# Altium schematic sheet 01_Interface.SchDoc
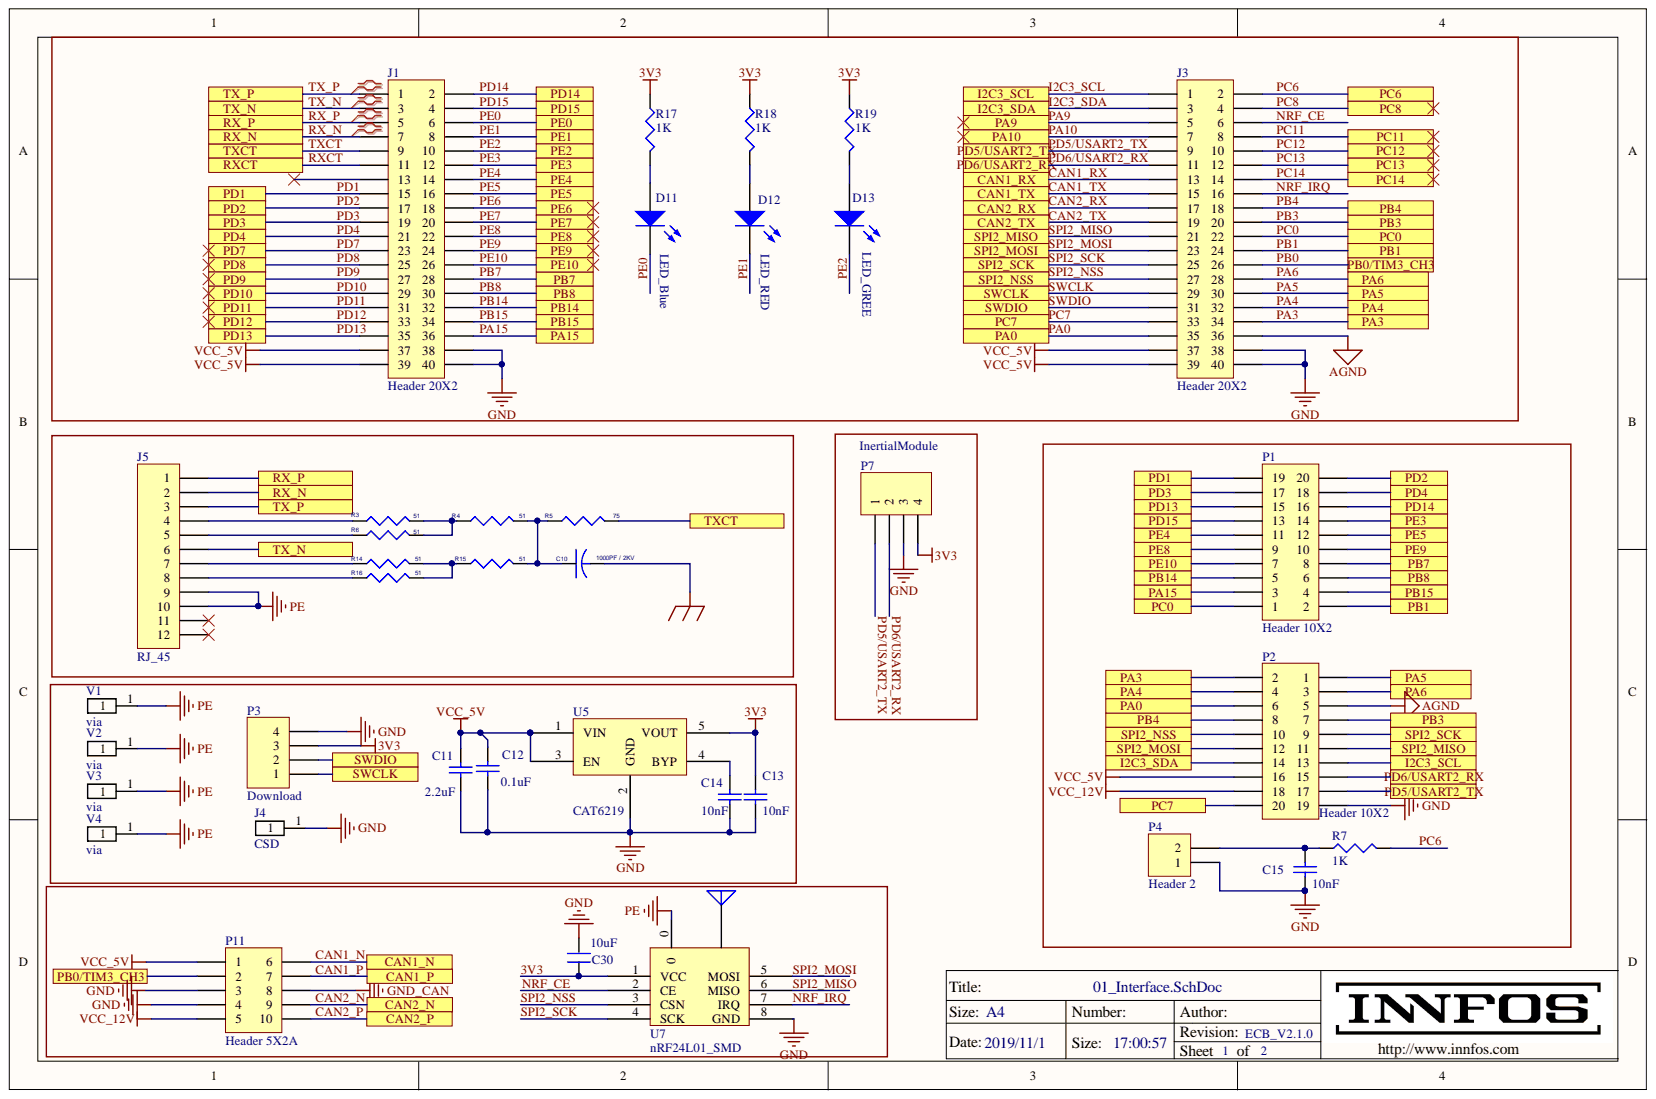
[diagram p0: 01_Interface.SchDoc]

## Parts
C10  1000PF / 2KV  [1206]
C11  2.2uF  [0402]
C12  0.1uF  [0402]
C13  10nF  [0402]
C14  10nF  [0402]
C15  10nF  [0402]
C30  10uF  [0402]
D11  LED_Blue  [LED_0603_BLUE]
D12  LED_RED  [LED_0603_RED]
D13  LED_GREE  [LED_0603_GREE]
J1  Header 20X2  [0_5_2_20_G]
J3  Header 20X2  [0_5_2_20_G]
J4  CSD  [CSD_5015]
J5  RJ_45  [RJ45_H5_5]
P1  Header 10X2  [2_10P_2_54]
P2  Header 10X2  [2_10P_2_54]
P3  Download  [Header_4p_2_54 _WZ]
P4  Header 2  [SM02B-GHS]
P7  InertialModule  [InertialModule]
P11  Header 5X2A  [Header_2_5P_2.54]
R3  51  [0402]
R4  51  [0402]
R5  75  [0402]
R6  51  [0402]
R7  1K  [0402]
R14  51  [0402]
R15  51  [0402]
R16  51  [0402]
R17  1K  [0402]
R18  1K  [0402]
R19  1K  [0402]
U5  CAT6219  [SOT23_5]
U7  nRF24L01_SMD  [nRF24L01_long]  (no BOM)
V1  via  [via_3_0]  (no BOM)
V2  via  [via_3_0]  (no BOM)
V3  via  [via_3_0]  (no BOM)
V4  via  [via_3_0]  (no BOM)

## Ports
CAN1_N, CAN1_P, CAN1_RX, CAN1_TX, CAN2_N, CAN2_P, CAN2_RX, CAN2_TX, I2C3_SCL, I2C3_SDA, PA0, PA3, PA4, PA5, PA6, PA9, PA10, PA15, PB0/TIM3_CH3, PB1, PB3, PB4, PB7, PB8, PB14, PB15, PC0, PC6, PC7, PC8, PC11, PC12, PC13, PC14, PD1, PD2, PD3, PD4, PD5/USART2_TX, PD6/USART2_RX, PD7, PD8, PD9, PD10, PD11, PD12, PD13, PD14, PD15, PE0, PE1, PE2, PE3, PE4, PE5, PE6, PE7, PE8, PE9, PE10, RX_N, RX_P, RXCT, SPI2_MISO, SPI2_MOSI, SPI2_NSS, SPI2_SCK, SWCLK, SWDIO, TX_N, TX_P, TXCT

## Nets
AGND: J3.36 P2.5
GND: C11.1 C12.1 C13.1 C14.1 C15.1 C30.2 J1.38 J1.40 J3.38 J3.40 J4.1 P2.19 P3.4 P4.1 P7.3 P11.3 P11.4 U5.2(GND) U7.8(GND)
GND_CAN: P11.8
PE: C10.2 J5.9 J5.10 U7.0 V1.1 V2.1 V3.1 V4.1
VCC_5V: C11.2 C12.2 J1.37 J1.39 J3.37 J3.39 P2.16 P11.1 U5.1(VIN) U5.3(EN)
VCC_12V: P2.18 P11.5
3V3: C13.2 C30.1 P3.3 P7.4 R17.1 R18.1 R19.1 U5.5(VOUT) U7.1(VCC)
CAN1_N: P11.6 ; port CAN1_N
CAN1_P: P11.7 ; port CAN1_P
CAN1_RX: J3.13 ; port CAN1_RX
CAN1_TX: J3.15 ; port CAN1_TX
CAN2_N: P11.9 ; port CAN2_N
CAN2_P: P11.10 ; port CAN2_P
CAN2_RX: J3.17 ; port CAN2_RX
CAN2_TX: J3.19 ; port CAN2_TX
I2C3_SCL: J3.1 P2.13 ; port I2C3_SCL
I2C3_SDA: J3.3 P2.14 ; port I2C3_SDA
NRF_CE: J3.6 U7.2(CE)
NRF_IRQ: J3.16 U7.7(IRQ)
PA0: J3.35 P2.6 ; port PA0
PA3: J3.34 P2.2 ; port PA3
PA4: J3.32 P2.4 ; port PA4
PA5: J3.30 P2.1 ; port PA5
PA6: J3.28 P2.3 ; port PA6
PA9: J3.5 ; port PA9
PA10: J3.7 ; port PA10
PA15: J1.36 P1.3 ; port PA15
PB0: J3.26 P11.2 ; port PB0/TIM3_CH3
PB1: J3.24 P1.2 ; port PB1
PB3: J3.20 P2.7 ; port PB3
PB4: J3.18 P2.8 ; port PB4
PB7: J1.28 P1.8 ; port PB7
PB8: J1.30 P1.6 ; port PB8
PB14: J1.32 P1.5 ; port PB14
PB15: J1.34 P1.4 ; port PB15
PC0: J3.22 P1.1 ; port PC0
PC6: J3.2 R7.2 ; port PC6
PC7: J3.33 P2.20 ; port PC7
PC8: J3.4 ; port PC8
PC11: J3.8 ; port PC11
PC12: J3.10 ; port PC12
PC13: J3.12 ; port PC13
PC14: J3.14 ; port PC14
PD1: J1.15 P1.19 ; port PD1
PD2: J1.17 P1.20 ; port PD2
PD3: J1.19 P1.17 ; port PD3
PD4: J1.21 P1.18 ; port PD4
PD5/USART2_TX: J3.9 P2.17 P7.1 ; port PD5/USART2_TX
PD6/USART2_RX: J3.11 P2.15 P7.2 ; port PD6/USART2_RX
PD7: J1.23 ; port PD7
PD8: J1.25 ; port PD8
PD9: J1.27 ; port PD9
PD10: J1.29 ; port PD10
PD11: J1.31 ; port PD11
PD12: J1.33 ; port PD12
PD13: J1.35 P1.15 ; port PD13
PD14: J1.2 P1.16 ; port PD14
PD15: J1.4 P1.13 ; port PD15
PE0: D11.2 J1.6 ; port PE0
PE1: D12.2 J1.8 ; port PE1
PE2: D13.2 J1.10 ; port PE2
PE3: J1.12 P1.14 ; port PE3
PE4: J1.14 P1.11 ; port PE4
PE5: J1.16 P1.12 ; port PE5
PE6: J1.18 ; port PE6
PE7: J1.20 ; port PE7
PE8: J1.22 P1.9 ; port PE8
PE9: J1.24 P1.10 ; port PE9
PE10: J1.26 P1.7 ; port PE10
RX_N: J1.7 J5.2 ; port RX_N
RX_P: J1.5 J5.1 ; port RX_P
RXCT: J1.11 ; port RXCT
SPI2_MISO: J3.21 P2.11 U7.6(MISO) ; port SPI2_MISO
SPI2_MOSI: J3.23 P2.12 U7.5(MOSI) ; port SPI2_MOSI
SPI2_NSS: J3.27 P2.10 U7.3(CSN) ; port SPI2_NSS
SPI2_SCK: J3.25 P2.9 U7.4(SCK) ; port SPI2_SCK
SWCLK: J3.29 P3.1 ; port SWCLK
SWDIO: J3.31 P3.2 ; port SWDIO
TX_N: J1.3 J5.6 ; port TX_N
TX_P: J1.1 J5.3 ; port TX_P
TXCT: J1.9 R5.2 ; port TXCT
NetC10_1: C10.1 R4.2 R5.1 R15.2
NetC14_2: C14.2 U5.4(BYP)
NetC15_2: C15.2 P4.2 R7.1
NetD11_1: D11.1 R17.2
NetD12_1: D12.1 R18.2
NetD13_1: D13.1 R19.2
NetJ5_4: J5.4 R3.1
NetJ5_5: J5.5 R6.1
NetJ5_7: J5.7 R14.1
NetJ5_8: J5.8 R16.1
NetR3_2: R3.2 R4.1 R6.2
NetR14_2: R14.2 R15.1 R16.2

Not connected: J1 13; J5 11 12

## Library data of the parts
C10 = CAPACITOR: Component Kind: Standard
C11 = Cap: Capacitor; Value: 10uf; Component Kind: Standard; LatestRevisionDate: 17-Jul-2002; LatestRevisionNote: Re-released for DXP Platform.; Library Name: Miscellaneous Devices.IntLib; PackageDescription: Radial Cap, Thru-Hole; 2 Leads; 0.3 in Pin Spacing; PackageReference: RAD-0.3; Published: 8-Jun-2000; Publisher: Altium Limited
C12 = Cap: Capacitor; Value: 100nF; Component Kind: Standard; LatestRevisionDate: 17-Jul-2002; LatestRevisionNote: Re-released for DXP Platform.; Library Name: Miscellaneous Devices.IntLib; PackageDescription: Radial Cap, Thru-Hole; 2 Leads; 0.3 in Pin Spacing; PackageReference: RAD-0.3; Published: 8-Jun-2000; Publisher: Altium Limited
C13-C15 = Cap: Capacitor; Component Kind: Standard; LatestRevisionDate: 17-Jul-2002; LatestRevisionNote: Re-released for DXP Platform.; Library Name: Miscellaneous Devices.IntLib; PackageDescription: Radial Cap, Thru-Hole; 2 Leads; 0.3 in Pin Spacing; PackageReference: RAD-0.3; Published: 8-Jun-2000; Value: 10nF; Publisher: Altium Limited
C30 = cap-1: Value: 10uF/25V; Component Kind: Standard; Library Name: MY_INTEGRATED_LIBRARY.IntLib
D11-D13 = LED(0603): Component Kind: Standard; Library Name: MY_INTEGRATED_LIBRARY.IntLib
J1 J3 = Header 20X2: Header, 20-Pin, Dual row; Component Kind: Standard; Library Name: Miscellaneous Connectors.IntLib
  J3: LatestRevisionDate: 17-Jul-2002; LatestRevisionNote: Re-released for DXP Platform.; Publisher: Altium Limited
J4 V1-V4 = Banana_female: Library Name: My_Schlib.SchLib
  J4: Component Kind: Standard
J5 = Header 12: Header, 12-Pin; Component Kind: Standard; LatestRevisionDate: 17-Jul-2002; LatestRevisionNote: Re-released for DXP Platform.; Library Name: Miscellaneous Connectors.IntLib; Publisher: Altium Limited
P1 P2 = Header 10X2: Header, 10-Pin, Dual row; Component Kind: Standard; LatestRevisionDate: 17-Jul-2002; LatestRevisionNote: Re-released for DXP Platform.; Library Name: Miscellaneous Connectors.IntLib; Publisher: Altium Limited
P3 P7 = Header 4: Header, 4-Pin; Component Kind: Standard; LatestRevisionDate: 17-Jul-2002; LatestRevisionNote: Re-released for DXP Platform.; Library Name: Miscellaneous Connectors.IntLib; Publisher: Altium Limited
P4 = Header 2: Header, 2-Pin; Component Kind: Standard; LatestRevisionDate: 17-Jul-2002; LatestRevisionNote: Re-released for DXP Platform.; Library Name: Miscellaneous Connectors.IntLib; Publisher: Altium Limited
P11 = Header 5X2A: Header, 5-Pin, Dual row; Component Kind: Standard; LatestRevisionDate: 17-Jul-2002; LatestRevisionNote: Re-released for DXP Platform.; Library Name: Miscellaneous Connectors.IntLib; Publisher: Altium Limited
R3-R6 R14-R16 = R: Component Kind: Standard
R7 R17-R19 = Res: Value: 22R; Component Kind: Standard; Library Name: My_Schlib.SchLib; PCB3D: Model Name
U5 = CAT6219: Component Kind: Standard; Library Name: My_Schlib.SchLib
U7 = nRF24L01_SMD: Library Name: My_Schlib.SchLib
